# Altium schematic sheet RF.SchDoc
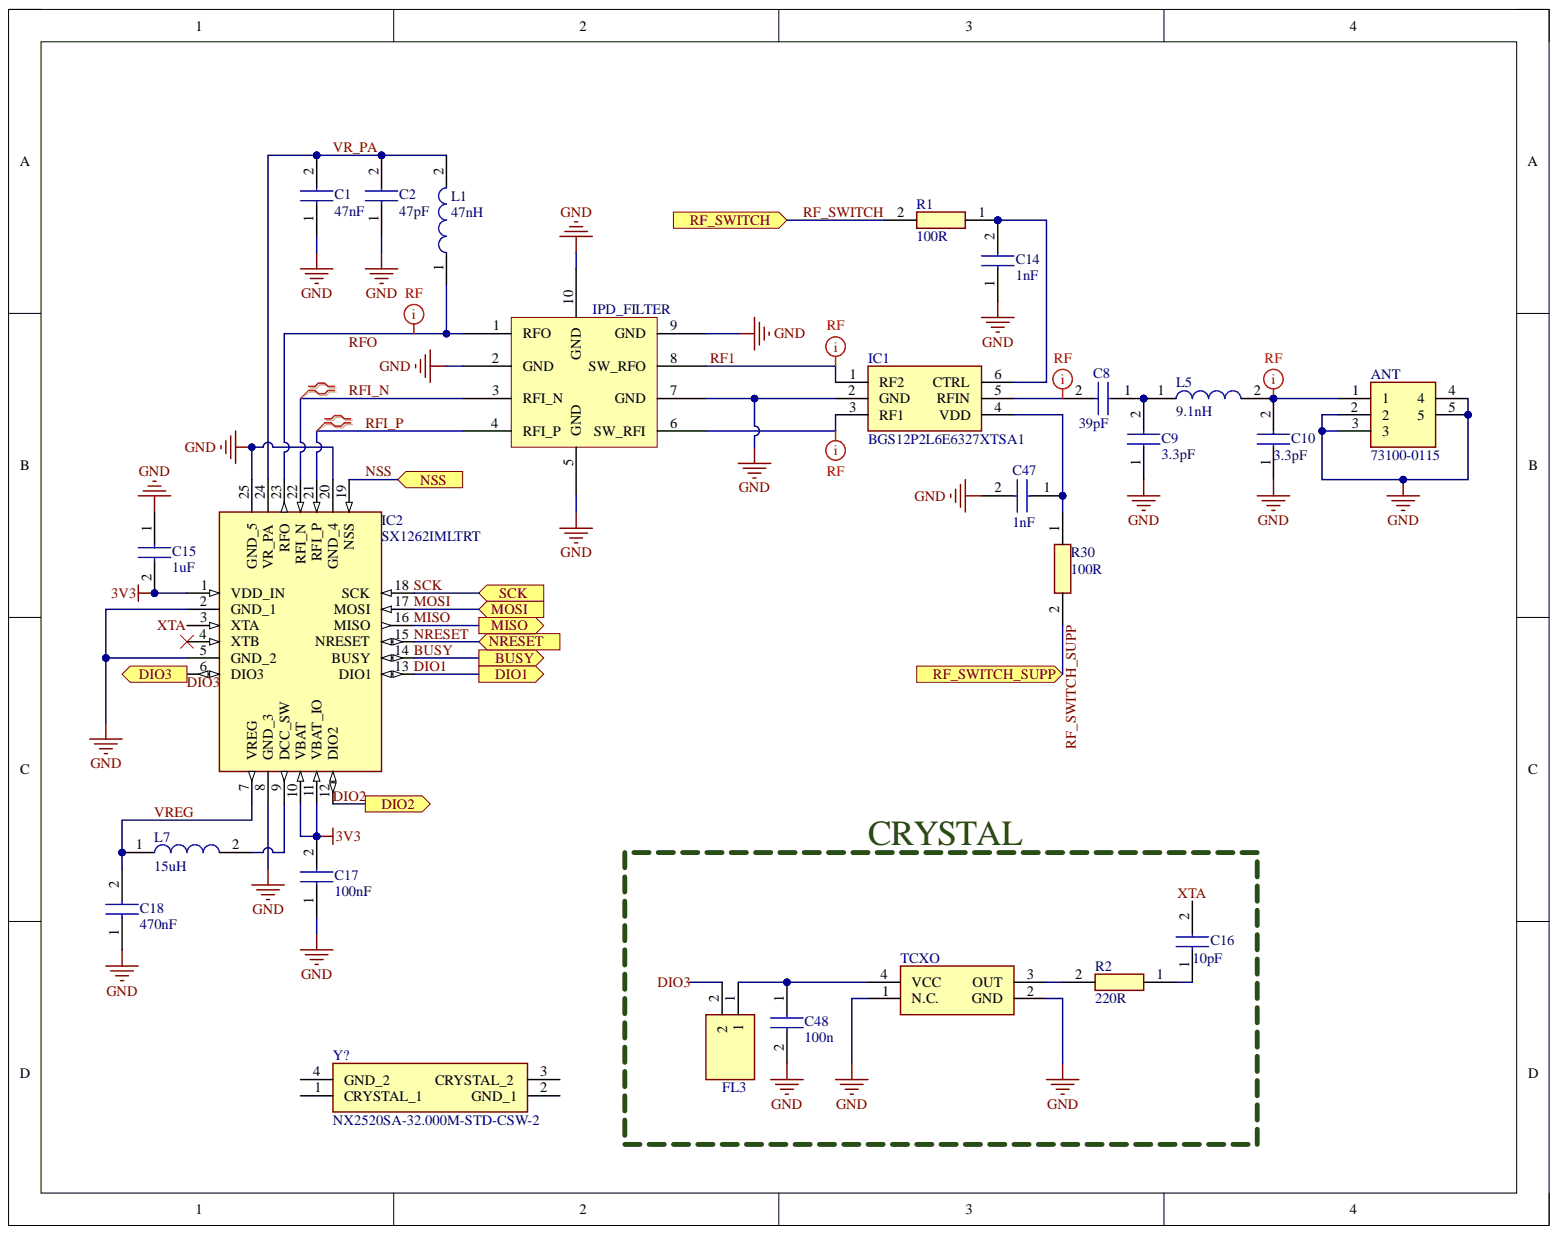
[diagram p0: RF.SchDoc]

## Parts
ANT  73100-0115  [731000115]
C1  47nF  [CAPC1005X55N]
C2  47pF  [CAPC1005X55N]
C8  39pF  [CAPC1005X55N]
C9  3.3pF  [CAPC1005X55N]
C10  3.3pF  [CAPC1005X55N]
C14  1nF  [CAPC1608X90N]
C15  1uF  [CAPC1005X55N]
C16  10pF  [CAPC1005X55N]
C17  100nF  [CAPC1005X55N]
C18  470nF  [CAPC1005X55N]
C47  1nF  [CAPC1608X90N]
C48  100n  [CAPC1005X55N]
FL3  BLM18PG181SN1D  [BLM18AG102BH1D]  (value not drawn)
IC1  BGS12P2L6E6327XTSA1  [BGS12P2L6E6327XTSA1]
IC2  SX1262IMLTRT  [QFN50P400X400X100-25N-D]
IPD_FILTER  IPD_FILTER  [IPD]
L1  47nH  [INDC1005X37N]
L5  9.1nH  [INDC1005X37N]
L7  15uH  [INDC1608X55N]
R1  100R  [RESC1005X35N]
R2  220R  [RESC1005X35N]
R30  100R  [RESC1005X35N]
TCXO  TG2520SMN_32.0000M-ECGNNM0  [TG2520SMN160000MMCGNNM3]  (value not drawn)
Y?  NX2520SA-32.000M-STD-CSW-2  [NX2520SA32000MSTDCSW2]

## Ports
BUSY, DIO1, DIO2, DIO3, MISO, MOSI, NRESET, NSS, RF_SWITCH, RF_SWITCH_SUPP, SCK

## Nets
3V3: C15.2 C17.2 IC2.1(VDD_IN) IC2.10(VBAT) IC2.11(VBAT_IO)
GND: ANT.2 ANT.3 ANT.4 ANT.5 C1.1 C2.1 C9.1 C10.1 C14.1 C15.1 C17.1 C18.1 C47.2 C48.2 IC1.2(GND) IC2.2(GND_1) IC2.5(GND_2) IC2.8(GND_3) IC2.20(GND_4) IC2.25(GND_5) IPD_FILTER.2(GND) IPD_FILTER.5(GND) IPD_FILTER.7(GND) IPD_FILTER.9(GND) IPD_FILTER.10(GND) TCXO.1(N.C.) TCXO.2(GND)
BUSY: IC2.14(BUSY) ; port BUSY
DIO1: IC2.13(DIO1) ; port DIO1
DIO2: IC2.12(DIO2) ; port DIO2
DIO3: FL3.2 IC2.6(DIO3) ; port DIO3
MISO: IC2.16(MISO) ; port MISO
MOSI: IC2.17(MOSI) ; port MOSI
NRESET: IC2.15(NRESET) ; port NRESET
NSS: IC2.19(NSS) ; port NSS
RF1: IC1.1(RF2) IPD_FILTER.8(SW_RFO)
RF_SWITCH: R1.2 ; port RF_SWITCH
RF_SWITCH_SUPP: R30.2 ; port RF_SWITCH_SUPP
RFI_N: IC2.22(RFI_N) IPD_FILTER.3(RFI_N)
RFI_P: IC2.21(RFI_P) IPD_FILTER.4(RFI_P)
RFO: IC2.23(RFO) IPD_FILTER.1(RFO) L1.1
SCK: IC2.18(SCK) ; port SCK
VR_PA: C1.2 C2.2 IC2.24(VR_PA) L1.2
VREG: C18.2 IC2.7(VREG) L7.1
XTA: C16.2 IC2.3(XTA)
NetANT_1: ANT.1 C10.2 L5.2
NetC8_1: C8.1 C9.2 L5.1
NetC8_2: C8.2 IC1.5(RFIN)
NetC14_2: C14.2 IC1.6(CTRL) R1.1
NetC16_1: C16.1 R2.1
NetC47_1: C47.1 IC1.4(VDD) R30.1
NetC48_1: C48.1 FL3.1 TCXO.4(VCC)
NetIC1_3: IC1.3(RF1) IPD_FILTER.6(SW_RFI)
NetIC2_9: IC2.9(DCC_SW) L7.2
NetR2_2: R2.2 TCXO.3(OUT)

Not connected: IC2 4(XTB); Y? 1(CRYSTAL_1) 2(GND_1) 3(CRYSTAL_2) 4(GND_2)

## Library data of the parts
ANT = 73100-0115: SMA Connector; Manufacturer_Name: Molex; Component Kind: Standard; Datasheet Link: https://www.molex.com/pdm_docs/sd/731000115_sd.pdf; Height: 9.57mm; Manufacturer_Part_Number: 73100-0115; Mouser Part Number: 538-73100-0115; Mouser Price/Stock: https://www.mouser.co.uk/ProductDetail/Molex/73100-0115?qs=dxVETAvtHz69wpck%252B7ItSw%3D%3D
C1 C2 C8-C10 C16-C18 = GRM155R61A224KE19D: 0402 Capacitor; Manufacturer_Name: Murata Electronics; Arrow Part Number: GRM155R61A224KE19D; Arrow Price/Stock: https://www.arrow.com/en/products/grm155r61a224ke19d/murata-manufacturing?region=nac; Component Kind: Standard; Datasheet Link: https://datasheet.datasheetarchive.com/originals/distributors/Datasheets_SAMA/ec4f1577dddcc7a13ddad897a28002d3.pdf; Height: 0.55mm; Manufacturer_Part_Number: GRM155R61A224KE19D; Mouser Part Number: 81-GRM155R61A224KE19; Mouser Price/Stock: https://www.mouser.co.uk/ProductDetail/Murata-Electronics/GRM155R61A224KE19D?qs=5bAuhL3RhmP2%252BUJqdGBuag%3D%3D
C14 C47 = GRM155R61A224KE19D: 0603 Capacitor; Manufacturer_Name: Murata Electronics; Arrow Part Number: GRM155R61A224KE19D; Arrow Price/Stock: https://www.arrow.com/en/products/grm155r61a224ke19d/murata-manufacturing?region=nac; Component Kind: Standard; Datasheet Link: https://datasheet.datasheetarchive.com/originals/distributors/Datasheets_SAMA/ec4f1577dddcc7a13ddad897a28002d3.pdf; Height: 0.55mm; Manufacturer_Part_Number: GRM155R61A224KE19D; Mouser Part Number: 81-GRM155R61A224KE19; Mouser Price/Stock: https://www.mouser.co.uk/ProductDetail/Murata-Electronics/GRM155R61A224KE19D?qs=5bAuhL3RhmP2%252BUJqdGBuag%3D%3D
C15 C48 = 06035C101K4T2A: 0402 Capacitor; Manufacturer_Name: AVX; Component Kind: Standard; Datasheet Link: https://componentsearchengine.com/Datasheets/1/06031A100FAT2A.pdf; Height: 0.9mm; Manufacturer_Part_Number: 06035C101K4T2A; Mouser Part Number: 581-06035C101K4T2A; Mouser Price/Stock: https://www.mouser.co.uk/ProductDetail/AVX/06035C101K4T2A/?qs=%252Bwax1qqoKK9kpvNfpp2NFA%3D%3D
  C48: Library Name: Schlib1.SchLib
FL3 = BLM18PG181SN1D: Filter; Manufacturer_Name: Murata Electronics; Arrow Part Number: BLM18PG181SN1D; Arrow Price/Stock: https://www.arrow.com/en/products/blm18pg181sn1d/murata-manufacturing?region=nac; Component Kind: Standard; Datasheet Link: https://www.murata.com/en-us/products/productdetail?partno=BLM18PG181SN1%23; Height: 0.95mm; Library Name: Schlib1.SchLib; Manufacturer_Part_Number: BLM18PG181SN1D; Mouser Part Number: 81-BLM18PG181SN1D; Mouser Price/Stock: https://www.mouser.co.uk/ProductDetail/Murata-Electronics/BLM18PG181SN1D?qs=sTaz8HHMol3W3rq0NJIneg%3D%3D
IC1 = BGS12P2L6E6327XTSA1: Integrated Circuit; Manufacturer_Name: Infineon; Arrow Part Number: BGS12P2L6E6327XTSA1; Arrow Price/Stock: https://www.arrow.com/en/products/bgs12p2l6e6327xtsa1/infineon-technologies-ag?region=nac; Component Kind: Standard; Datasheet Link: https://www.arrow.com/en/products/bgs12p2l6e6327xtsa1/infineon-technologies-ag; Height: 0.32mm; Library Name: Schlib1.SchLib; Manufacturer_Part_Number: BGS12P2L6E6327XTSA1; Mouser Part Number: 726-BGS12P2L6E6327XT; Mouser Price/Stock: https://www.mouser.co.uk/ProductDetail/Infineon-Technologies/BGS12P2L6E6327XTSA1?qs=mAH9sUMRCtuiUSQTWc4Zyg%3D%3D
IC2 = SX1262IMLTRT: Integrated Circuit; Manufacturer_Name: SEMTECH; Arrow Part Number: SX1262IMLTRT; Arrow Price/Stock: https://www.arrow.com/en/products/sx1262imltrt/semtech?region=nac; Component Kind: Standard; Datasheet Link: http://www.mouser.com/datasheet/2/761/DS_SX1261-2_V1.1-1307803.pdf; Height: 1mm; Manufacturer_Part_Number: SX1262IMLTRT; Mouser Part Number: 947-SX1262IMLTRT; Mouser Price/Stock: https://www.mouser.co.uk/ProductDetail/Semtech/SX1262IMLTRT?qs=XFmntM7Yc9eYt6yxTPughQ%3D%3D
L1 L5 = ATFC-0402-0N2-B-T: 0402 Inductor; Manufacturer_Name: ABRACON; Component Kind: Standard; Datasheet Link: http://www.mouser.com/ds/2/3/ATFC-0402-6001.pdf; Height: 0.37mm; Manufacturer_Part_Number: ATFC-0402-0N2-B-T; Mouser Part Number: 815-ATFC-0402-0N2B; Mouser Price/Stock: https://www.mouser.co.uk/ProductDetail/ABRACON/ATFC-0402-0N2-B-T?qs=nwAb7ZGSLg5V%252BGnp0AyMzg%3D%3D
L7 = ATFC-0603-22N-FT: 0603 Inductor; Manufacturer_Name: ABRACON; Component Kind: Standard; Datasheet Link: https://datasheet.datasheetarchive.com/originals/distributors/Datasheets-DGA11/2214358.pdf; Height: 0.55mm; Manufacturer_Part_Number: ATFC-0603-22N-FT; Mouser Part Number: 815-ATFC-0603-22NF; Mouser Price/Stock: https://www.mouser.com/Search/Refine.aspx?Keyword=815-ATFC-0603-22NF
R1 R2 R30 = CPF0402B107KE1: 0402 Resistor; Manufacturer_Name: TE Connectivity; Component Kind: Standard; Datasheet Link: http://www.farnell.com/datasheets/1786775.pdf; Height: 0.35mm; Manufacturer_Part_Number: CPF0402B107KE1; Mouser Part Number: 279-CPF0402B107KE1; Mouser Price/Stock: https://www.mouser.co.uk/ProductDetail/TE-Connectivity-Neohm/CPF0402B107KE1?qs=CXI0wBT1M2hGHjTlP2dotA%3D%3D
TCXO = TG2520SMN_32.0000M-ECGNNM0: 32MHz TCXO; Manufacturer_Name: Epson Timing; Manufacturer_Part_Number: TG2520SMN 32.0000M-ECGNNM0; Component Kind: Standard; Datasheet Link: https://support.epson.biz/td/api/doc_check.php?dl=brief_TG2520SMN&lang=en; Height: 0.8mm
Y? = NX2520SA-32.000M-STD-CSW-2: Crystal or Oscillator; Manufacturer_Name: NDK; Component Kind: Standard; Datasheet Link: https://media.digikey.com/pdf/Data%20Sheets/NDK%20PDFs/NX2520SA_16-48MHZ.pdf; Height: 0.55mm; Manufacturer_Part_Number: NX2520SA-32.000M-STD-CSW-2; Mouser Part Number: 344-NX2520SA32MCSW2; Mouser Price/Stock: https://www.mouser.co.uk/ProductDetail/NDK/NX2520SA-32000M-STD-CSW-2?qs=55YtniHzbhDYadAn5DgF2A%3D%3D
IPD_FILTER = IPD_FILTER: Component Kind: Standard; Library Name: Telemetry_CustomLib.SchLib
